# Altium schematic sheet ADC.SchDoc(ADC)
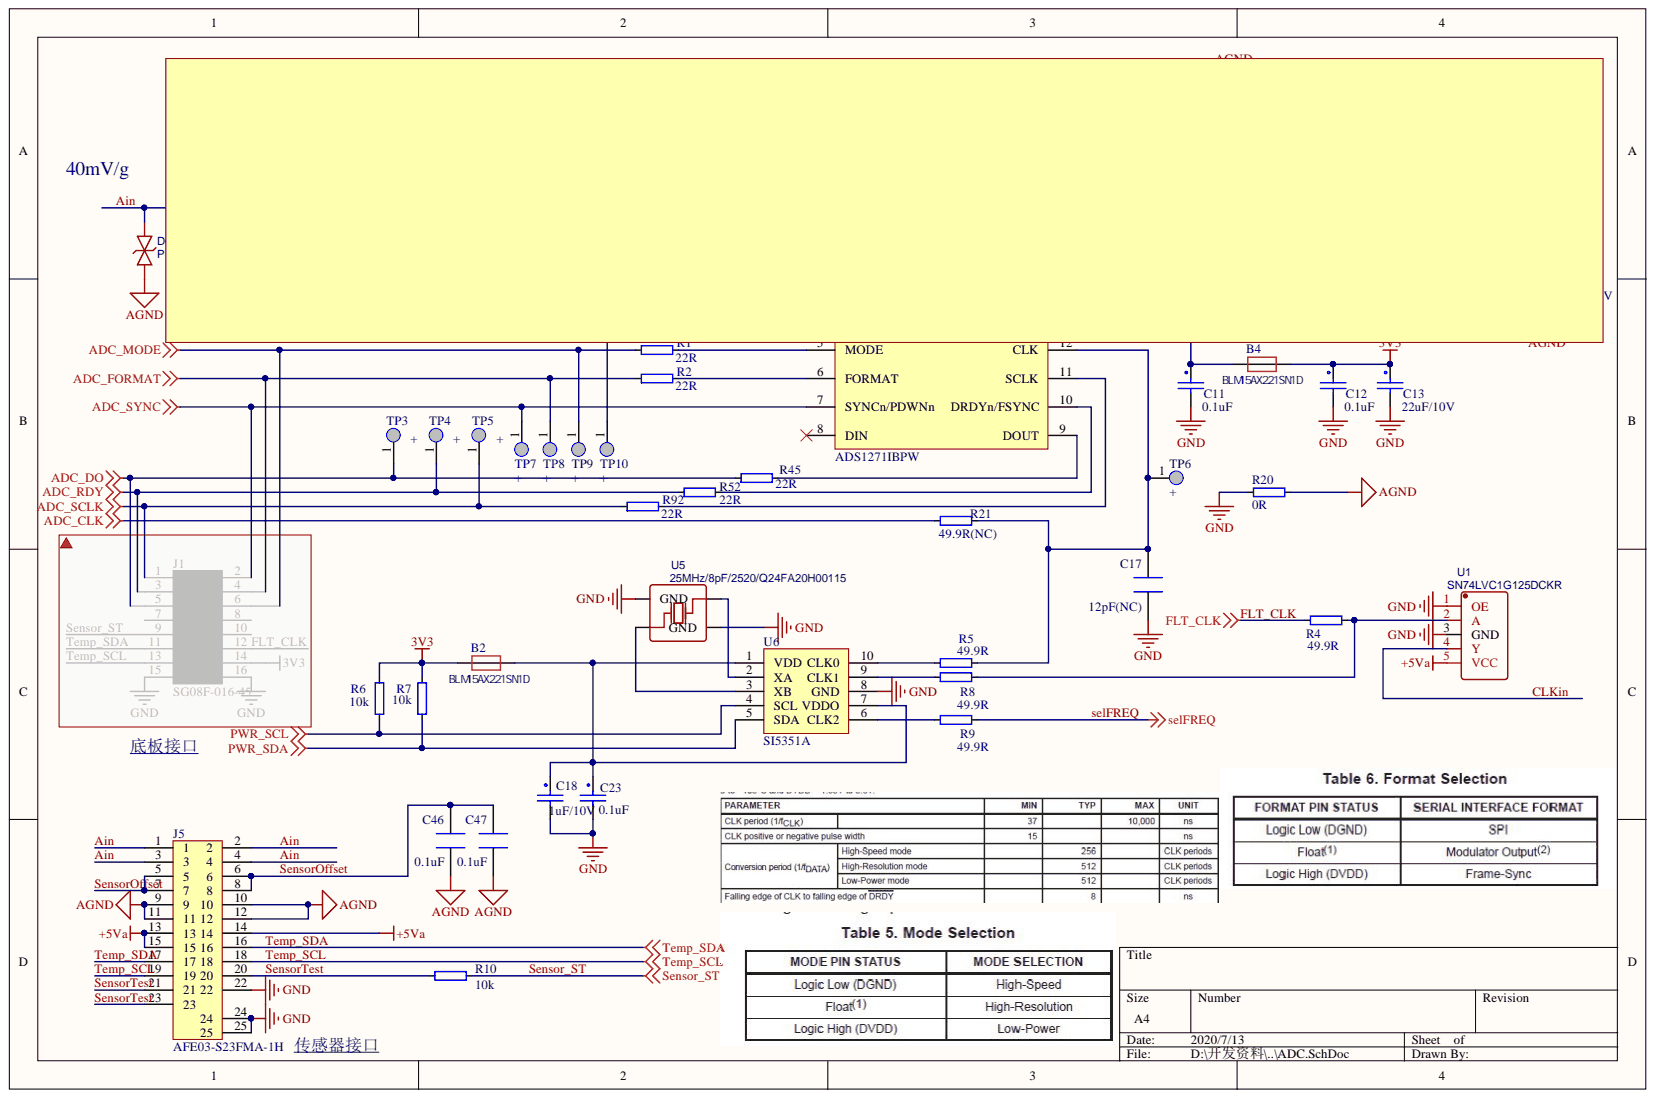
[diagram p0: ADC.SchDoc]

## Parts
B2  BLM15AX221SN1D  [B0402]
B4  BLM15AX221SN1D  [B0402]
C1  22uF/10V  [0603_C]
C2  22uF/10V  [0603_C]
C3  0.1uF  [0402_C]
C4  0.1uF  [0402_C]
C5  22uF/10V  [0603_C]
C6  0.1uF  [0402_C]
C7  22uF/10V  [0603_C]
C8  300pF  [0402_C]
C9  22uF/10V  [0603_C]
C10  22uF/10V  [0603_C]
C11  0.1uF  [0402_C]
C12  0.1uF  [0402_C]
C13  22uF/10V  [0603_C]
C16  300pF  [0402_C]
C17  12pF(NC)  [0402_C]
C18  1uF/10V  [0402_C]
C23  0.1uF  [0402_C]
C33  0.1uF  [0402_C]
C46  0.1uF  [0402_C]
C47  0.1uF  [0402_C]
C73  1uF/10V  [0402_C]
D6  PESD05NFA  [D0402-BI]
J5  AFE03-S23FMA-1H  [FPC-SMD_P0.30-23P_FGS-H1.0]
R1  22R  [0402]
R2  22R  [0402]
R4  49.9R  [0402]
R5  49.9R  [0402]
R6  10k  [0402]
R7  10k  [0402]
R8  49.9R  [0402]
R9  49.9R  [0402]
R10  10k  [0402]
R13  16K  [0402]
R17  0R  [0402]
R18  32K  [0402]
R19  0R  [0402]
R20  0R  [0402]
R21  49.9R(NC)  [0402]
R44  100R  [0402]
R45  22R  [0402]
R52  22R  [0402]
R92  22R  [0402]
R108  0R(NC)  [0402]
TP2  +  [TP]
TP3  +  [TP]
TP4  +  [TP]
TP5  +  [TP]
TP6  +  [TP]
TP7  +  [TP]
TP8  +  [TP]
TP9  +  [TP]
TP10  +  [TP]
U1  SN74LVC1G125DCKR  [SOT-353_L2.2-W1.4-P0.65-LS2.4-BL]
U2  LTC1569-6  [SOP-8]
U3  MCP1501T-20E  [SOT23-6]
U4  ADS1271IBPW  [TSSOP-16_16PIN-L5.10-W6.60-PITCH0.65-BL]
U5  25MHz/8pF/2520/Q24FA20H00115  [OSC-SMD_4P-L2.5-W2.0-BL]
U6  SI5351A  [MSOP-10]

## Nets
3V3: B2.2 B4.2 C12.1 C13.1 R6.1 R7.1
+5VA: C5.1 C6.1 C10.1 J5.13 J5.14 J5.15 U1.5(VCC) U2.7(V+) U3.4(SHUT) U3.6(VDD) U4.4(AVDD)
ADC_CLK: R21.2
ADC_DO: R45.2 TP3.1
ADC_FORMAT: R2.2 TP8.1
ADC_MODE: R1.2 TP9.1
ADC_RDY: R52.2 TP4.1
ADC_SCLK: R92.2 TP5.1
ADC_SYNC: TP7.1 U4.7(SYNCn/PDWNn)
AGND: C1.2 C2.2 C3.1 C4.2 C5.2 C6.2 C7.2 C8.2 C9.2 C10.2 C16.2 C33.2 C46.2 C47.2 C73.2 D6.1 J5.9 J5.10 J5.11 J5.12 R20.1 U2.4(V-) U2.6(RX) U3.2(GND) U3.3(GND) U3.5(GND) U4.3(AGND) U4.15(VREFN)
GND: C11.2 C12.2 C13.2 C17.2 C18.2 C23.2 J5.22 J5.24 J5.25 R20.2 U1.1(OE) U1.3(GND) U4.14(DGND) U5.2(GND) U5.4(GND) U6.8(GND)
PWR_SCL: R6.2 U6.4(SCL)
PWR_SDA: R7.2 U6.5(SDA)
AIN: D6.2 J5.1 J5.2 J5.3 J5.4 R13.2
CLKIN: TP10.1 U1.4(Y) U2.5(CLK)
FLT_CLK: R4.2
SELFREQ: R9.1
SENSOR_ST: R10.2
SENSOROFFSET: C46.1 C47.1 J5.5 J5.6 J5.7 J5.8 U4.2(AINN)
SENSORTEST: J5.20 J5.21 J5.23 R10.1
TEMP_SCL: J5.18 J5.19
TEMP_SDA: J5.16 J5.17
VREF: C3.2 C7.1 R17.1 U2.2(IN-) U2.3(GND)
NetB2_1: B2.1 C18.1 C23.1 U6.1(VDD) U6.7(VDDO)
NetB4_1: B4.1 C11.1 U4.13(DVDD)
NetC1_1: C1.1 C2.1 C4.1 C73.1 R17.2 R19.1 U3.1(OUT)
NetC8_1: C8.1 R18.1 R108.2 TP2.1 U2.1(IN+)
NetC9_1: C9.1 R19.2 U4.16(VREFP)
NetC16_1: C16.1 R13.1 R18.2
NetC17_1: C17.1 R5.1 R21.1 TP6.1 U4.12(CLK)
NetC33_1: C33.1 R44.1 U4.1(AINP)
NetR1_1: R1.1 U4.5(MODE)
NetR2_1: R2.1 U4.6(FORMAT)
NetR4_1: R4.1 R8.1 U1.2(A)
NetR5_2: R5.2 U6.10(CLK0)
NetR8_2: R8.2 U6.9(CLK1)
NetR9_2: R9.2 U6.6(CLK2)
NetR44_2: R44.2 R108.1 U2.8(OUT)
NetR45_1: R45.1 U4.9(DOUT)
NetR52_1: R52.1 U4.10(DRDYn/FSYNC)
NetR92_1: R92.1 U4.11(SCLK)
NetU5_1: U5.1 U6.3(XB)
NetU5_3: U5.3 U6.2(XA)

Not connected: U4 8(DIN)

## Library data of the parts
B2 B4 = Bead: Component Kind: Standard; Library Name: RP3288BV03_20180126-.SCHLIB
C1 C2 C5 C7 C9 C10 C13 C18 C73 = CAP_100N_25V_0402_10%_X5R: 100nF; MANUFACTURER: TAIYO YUDEN; PART: TMK105BJ104KV-F; Component Kind: Standard; FARNELL: 1611916; Library Name: Arbury_C.SchLib
C3 C4 C6 C11 C12 C23 C33 = CAP_100N_25V_0402_10%_X5R: MANUFACTURER: TAIYO YUDEN; PART: TMK105BJ104KV-F; Component Kind: Standard; Description: 0.1uF; FARNELL: 1611916; Library Name: Arbury_C.SchLib
C8 C16 = CAP_100N_25V_0402_10%_X5R: 0.1uF; MANUFACTURER: TAIYO YUDEN; PART: TMK105BJ104KV-F; Component Kind: Standard; FARNELL: 1611916; Library Name: Arbury_C.SchLib
C17 = STD-06-0048: CHIP CAPACITOR, CERAMIC, 100NF, 16V, 10%, X7R, 0402; Manufacturer: Yageo; Manufacturer Part Number: CC0402KRX7R7BB104; Approx Volume Cost ($): 0.003; Database Table Name: MLCC$; VALUE: 0.1; Component Kind: Standard; Dielectric: X7R; Part Number: STD-06-0048; Rated Voltage: 16V; Tolerance: 10%; Library Name: JG_StandardLibrary.DbLib
C46 C47 = STD-06-0048: CHIP CAPACITOR, CERAMIC, 100NF, 16V, 10%, X7R, 0402; Manufacturer: Yageo; Manufacturer Part Number: CC0402KRX7R7BB104; Approx Volume Cost ($): 0.003; Database Table Name: MLCC$; Component Kind: Standard; Dielectric: X7R; Part Number: STD-06-0048; Rated Voltage: 16V; Tolerance: 10%; VALUE: 0.1uF; Library Name: JG_StandardLibrary.DbLib
D6 = PESD05NFA: BOM_Manufacturer: PTTC(Polytronics Tech); Contributor: LCSC; BOM_Manufacturer Part: PESD05NFA; BOM_Supplier: LCSC; BOM_Supplier Part: C438894; Component Kind: Standard; spicePre: D; spiceSymbolName: PESD05NFA
R1 R2 R4-R10 R13 R17-R21 R44 R45 R52 R92 R108 = R0402-12k: Resistor, ?%, 0.063W; Artikkelnr Noca: 27049099; Database Table Name: Resistors$; Note: Chip Res 1%; Value: 12k; Component Kind: Standard; LatestRevisionDate: 2009-11-23; Library Name: Nordic Passive DB.DbLib; Part Number: R0402-12k; Pin Count: 2; Published: 2009-05-08; Supplier: Farnell; Supplier part number: 173-8865; Publisher: Nordic Semiconductor
TP2-TP10 = TP: Component Kind: Standard; Library Name: mysch.SchLib
U1 = SN74LVC1G125DCKR: BOM_Manufacturer: TI; SMT Type: Extend; BOM_LCSC Assembly: Yes; BOM_Manufacturer Part: SN74LVC1G125DCKR; BOM_Supplier: LCSC; BOM_Supplier Part: C7833; Component Kind: Standard; image: //image.lceda.cn/szlcsc/C7833.jpg; spicePre: X; spiceSymbolName: SN74LVC1G125DCKR
U3 = MCP1501T: Component Kind: Standard; Library Name: Schlib1.SchLib
U4 = ADS1271: Component Kind: Standard; Library Name: RT1052_CORE_P120.lib
U5 = 25MHz: BOM_Manufacturer: Seiko Epson; Contributor: LCSC; nameAlias: Frequency; BOM_Manufacturer Part: Q24FA20H00115; BOM_Supplier: LCSC; BOM_Supplier Part: C255957; Component Kind: Standard; spicePre: X; spiceSymbolName: Q24FA20H00115
J5 = AFE03-S23FMA-1H: Component Kind: Standard; Library Name: Schlib1.SchLib
U2 = LTC1569-6: Component Kind: Standard; Library Name: Schlib1.SchLib
U6 = SI5351A: Component Kind: Standard; Library Name: Schlib1.SchLib
